# Altium schematic sheet CP2102_USB_To_UART.SchDoc
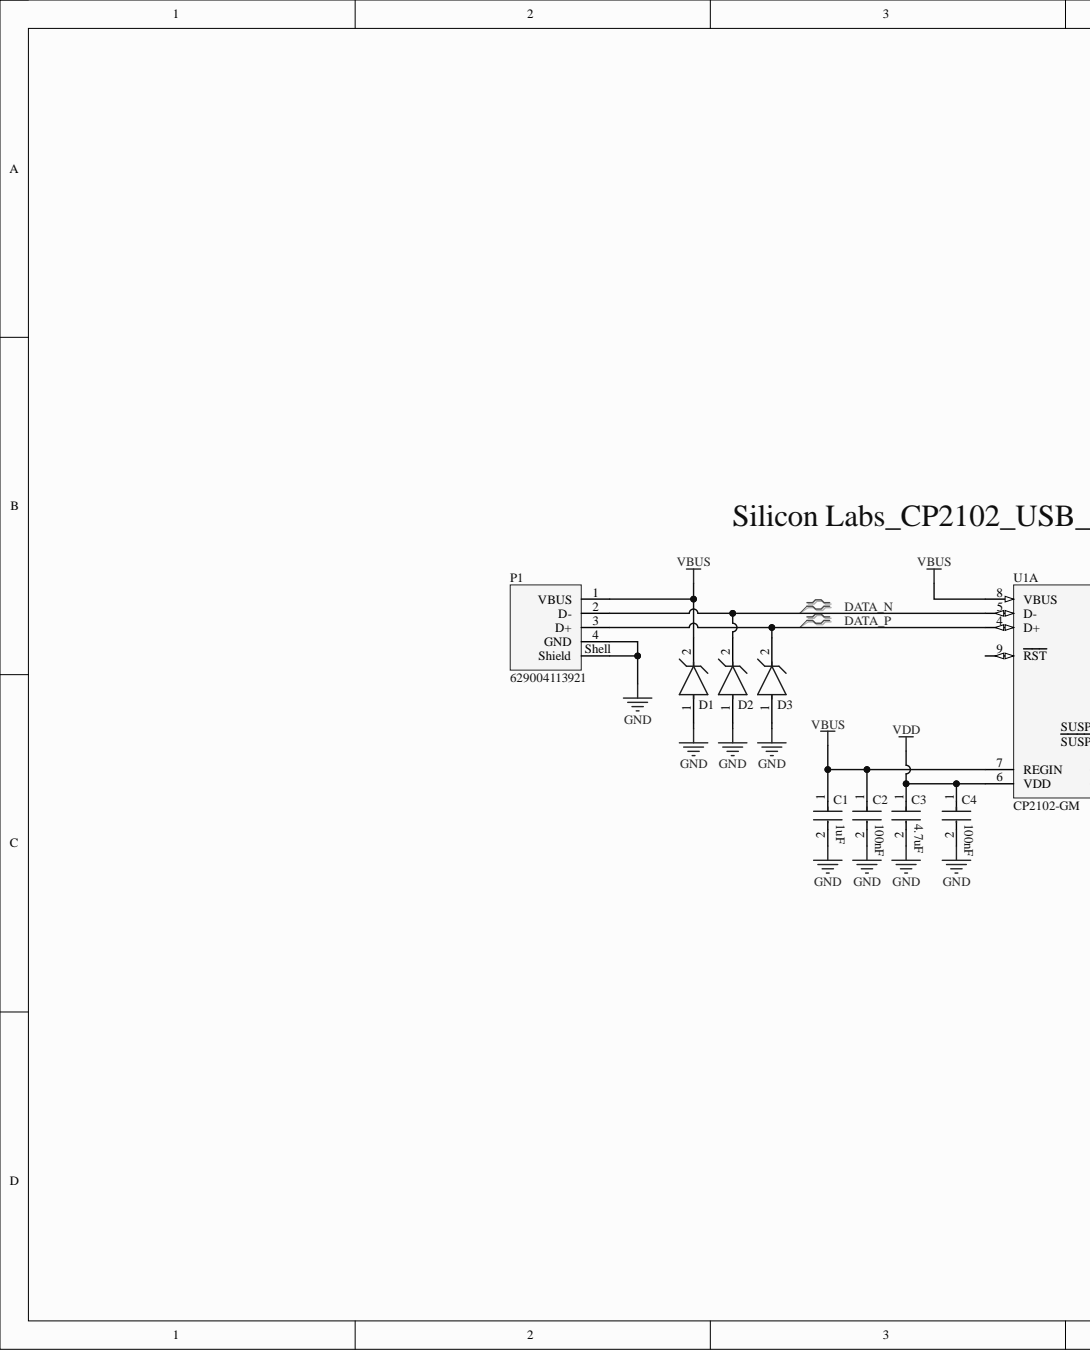
[diagram p0: CP2102_USB_To_UART.SchDoc, left]
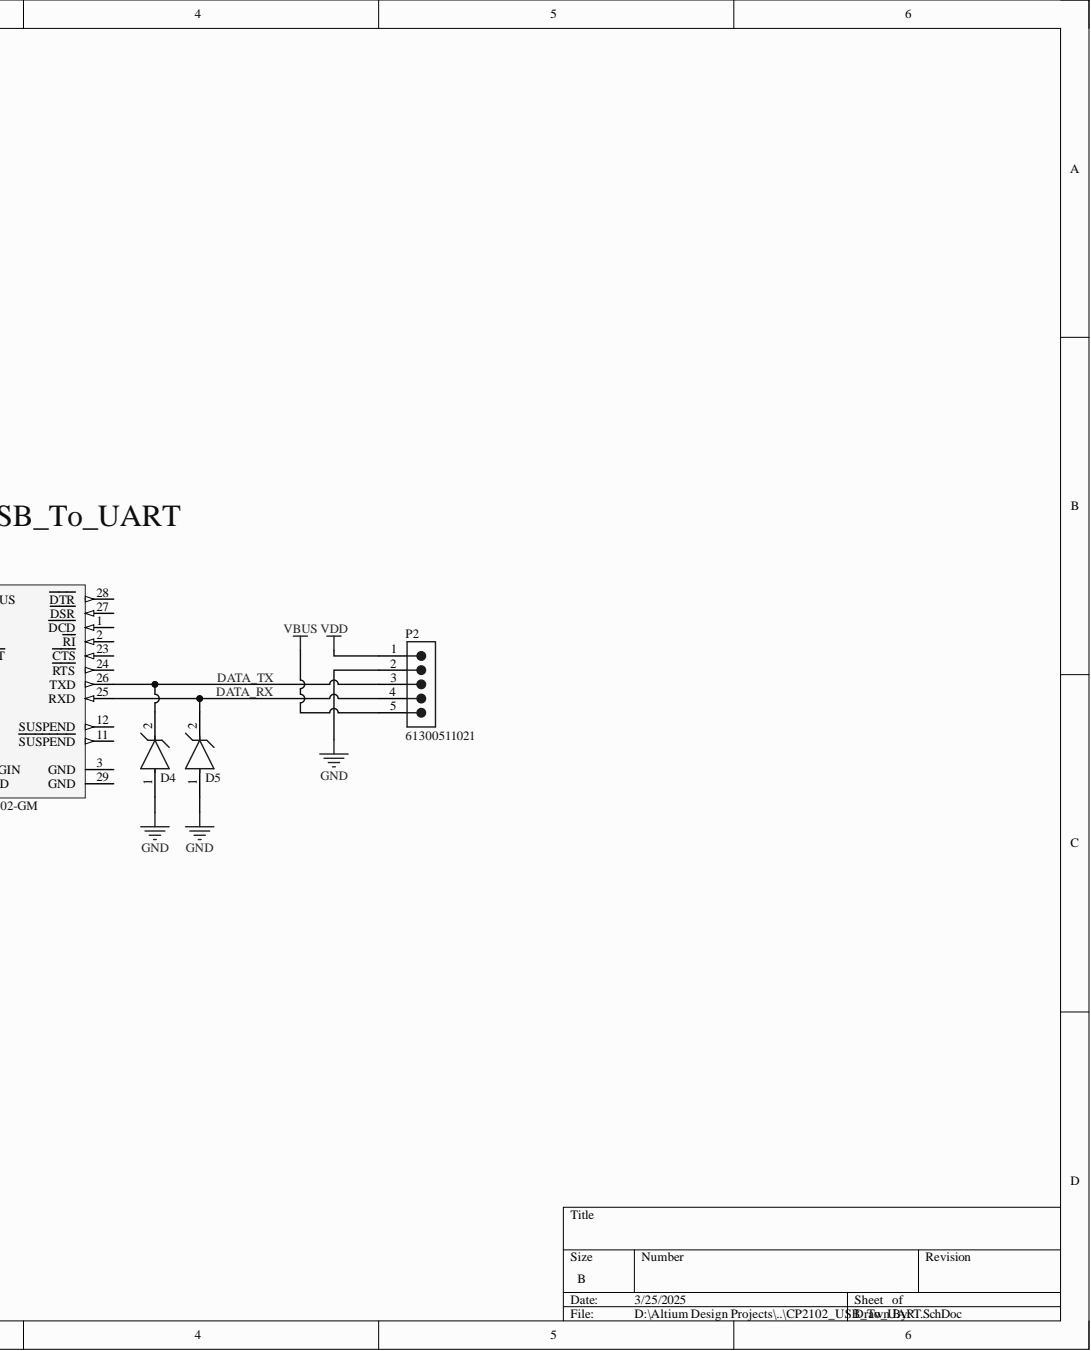
[diagram p1: CP2102_USB_To_UART.SchDoc, right]

## Parts
C1  value 1uF  Capacitance: 1uF  [FP-CC0402-MFG]  (hidden comment: CC0402KRX5R6BB105)
C2  Capacitance: 100nF  [FP-C1005-050-0_05-MFG]  (hidden comment: C1005X7R1C104K050BC)
C3  Capacitance: 4.7uF  [FP-GRM155-0_15-MFG]  (hidden comment: GRM155R61A475MEAAD)
C4  Capacitance: 100nF  [FP-C1005-050-0_05-MFG]  (hidden comment: C1005X7R1C104K050BC)
D1  UCLAMP3601P.TNT  [FP-SLP1006P2-MFG]  (value not drawn)
D2  UCLAMP3601P.TNT  [FP-SLP1006P2-MFG]  (value not drawn)
D3  UCLAMP3601P.TNT  [FP-SLP1006P2-MFG]  (value not drawn)
D4  UCLAMP3601P.TNT  [FP-SLP1006P2-MFG]  (value not drawn)
D5  UCLAMP3601P.TNT  [FP-SLP1006P2-MFG]  (value not drawn)
P1  629004113921  [629004113921]
P2  61300511021  [61300511021]
U1  CP2102-GM  [SLAB-QFN-28-3125x3125TP_N]  (part A)

## Nets
GND: C1.2 C2.2 C3.2 C4.2 D1.1 D2.1 D3.1 D4.1 D5.1 P1.4(GND) P1.Shell(Shield) P2.2
VBUS: C1.1 C2.1 D1.2 P1.1(VBUS) P2.5 U1.7(REGIN) U1.8(VBUS)
VDD: C3.1 C4.1 P2.1 U1.6(VDD)
DATA_N: D2.2 P1.2(D-) U1.5(D-)
DATA_P: D3.2 P1.3(D+) U1.4(D+)
DATA_RX: D5.2 P2.4 U1.25(RXD)
DATA_TX: D4.2 P2.3 U1.26(TXD)

Not connected: U1 1(DCD) 2(RI) 3(GND) 9(RST) 11(SUSPEND) 12(SUSPEND) 23(CTS) 24(RTS) 27(DSR) 28(DTR) 29(GND)

## Library data of the parts
C1 = CMP-03422-000489-1: CAP CER 1UF 10V X5R 0402; Manufacturer: YAGEO; Automotive Grade: NA; Material: Ceramic; Temperature Coefficient: 30ppm/°C; Tolerance: 0.1; Automotive: No; Category: Cap; Component Kind: Standard; ComponentLink2Description: Datasheet; ComponentLink2URL: http://www.yageo.com/documents/recent/UPY-GP_NP0_16V-to-50V_16.pdf; Device Class L1: Passive Components; Device Class L2: Capacitors; Device Class L3: Ceramic Capacitors; Digikey Description: CAP CER 1UF 10V X5R 0402; Footprint Url: http://www.yageo.com/exep/pages/download/literatures/UPY-C_GEN_24.pdf; Height: 0.55mm; Ipc Land Pattern Name: CAPC10050X50; Lead Free: Yes; Library Name: Altium Content Vault; Manufacturer Part Number: CC0402KRX5R6BB105; Mouser Description: Multilayer Ceramic Capacitors MLCC - SMD/SMT 1uF 10V X5R 10%; Octopart: https://octopart.com/cc0402krx5r6bb105-yageo-42244620; Package: CC0402; RoHS: TRUE; Temperature: -55°C to +125°C; Temperature Characteristic: X5R; Temperature Range High: +125°C; Temperature Range Low: -55°C; Voltage Rating: 10 V
C2 C4 = CMP-08246-004182-1: Multilayer Ceramic Capacitors 100nF ±10% 16V X7R SMD 0402; Case Code (Imperial): 0402; Case Code (Metric): 1005; Case/Package: 0402; Component Kind: Standard; Construction: Flat; Depth: 0.5mm; Dielectric: X7R; Dielectric Material: Ceramic; Height: 0.5mm; Lead Free: Lead Free; Length: 1mm; Library Name: Altium Content Vault; Max Operating Temperature: 125°C; Min Operating Temperature: -55°C; Mount: Surface Mount; Number of Pins: 2; Package Quantity: 1; Packaging: Tape and Reel; Radiation Hardening: No; Resistor Type: Ceramic; RoHS Compliant: Yes; Series: C; Termination: SMD/SMT; Thickness: 0.022inch; Tolerance: 10%; Voltage: 16V; Voltage Rating: 16V; Voltage Rating (DC): 16V; Weight: 2.3E-05oz; Width: 0.02inch
C3 = CMP-06035-003573-1: Chip Multilayer Ceramic Capacitors for General Purpose, 0402, 4.7uF, X5R, 15%, 20%, 10V; Case Code (Imperial): 0402; Case Code (Metric): 1005; Case/Package: 0402; Component Kind: Standard; Library Name: Altium Content Vault; Max Operating Temperature: 85°C; Min Operating Temperature: -55°C; Mount: Surface Mount; Packaging: Tape and Reel; Tolerance: 20%; Voltage Rating: 10V
D1-D5 = CMP-56445-000005-1: TVS DIODE 33VWM 60VC SLP1006P2; Clamping Voltage: 60V; Diode Type: ZENER; Direction: Unidirectional; Element Configuration: Single; Leakage Current: 1uA; Max Breakdown Voltage: 45V; Min Breakdown Voltage: 36V; Number of Unidirectional Channels: 1; Peak Pulse Current: 2A; Peak Pulse Power: 125W; Power Dissipation: 170W; Power Line Protection: No; Reverse Standoff Voltage: 33V; Case Code (Imperial): 0402; Case Code (Metric): 1006; Case/Package: SMD/SMT; Clamping Voltage-Max: 60V; Component Kind: Standard; Depth: 0.6mm; Lead Free: Lead Free; Length: 1mm; Library Name: Altium Content Vault; Max Operating Temperature: 125°C; Min Operating Temperature: -40°C; Mount: Surface Mount; Number of Pins: 2; Packaging: Tape and Reel; Radiation Hardening: No; Resistor Type: Zener; RoHS Compliant: Yes; Series: Automotive, AEC-Q100, uClamp®; Working Voltage: 33V
P1 = CMP-1502-03242-2: NexarPartId: 19991169; Connector Type: USB; Contact Resistance: 20mΩ; Current Rating: 1.5A; Gender: Male; Insulation Resistance: 1GΩ; Number of Contacts: 4; Operating Supply Voltage: 30V; USB Standard: USB 2.0; Component Kind: Standard; Contact Plating: Gold; Features: Shielded; Lead Free: Lead Free; Length: 18.8mm; Library Name: Altium Content Vault; Max Operating Temperature: 105°C; Min Operating Temperature: -40°C; Mount: Horizontal, Surface Mount; Number of Pins: 4; Orientation: Horizontal; REACH SVHC: Unknown; RoHS: Compliant; Termination: SMD/SMT
P2 = CMP-1502-01067-3: NexarPartId: 32855525; Connector Type: Male Pin; Contact Resistance: 20mΩ; Current Rating: 3A; Gender: Male; Number of Contacts: 5; Operating Supply Voltage: 250V; Pitch: 2.54mm; Color: Black; Component Kind: Standard; Contact Material: Copper; Contact Mating Length: 5.9944mm; Contact Plating: Gold; Lead Pitch: 2.54mm; Library Name: Altium Content Vault; Mount: Through Hole; Number of Pins: 5; Number of Positions: 5; Number of Rows: 1; Packaging: Bulk; REACH SVHC: Unknown; RoHS: Compliant; Termination: Solder
U1 = CMP-0511-00012-1: Single-Chip USB to UART Bridge, 1024 Bytes EEPROM, -40 to 85 degC, 28-pin QFN, Tube; Manufacturer: Silicon Labs; Internal Oscillator: TRUE; Sub-Family: USB Bridges; Code_IPC: J-STD-020C; Code_JEDEC: MO-220; Component Kind: Standard; ComponentLink1Description: Manufacturer URL; ComponentLink1URL: http://www.silabs.com/; ComponentLink2Description: Datasheet; ComponentLink2URL: http://www.silabs.com/Support%20Documents/TechnicalDocs/CP2102-9.pdf; ComponentLink3Description: Package Specification; ComponentLink3URL: http://www.silabs.com/Support%20Documents/TechnicalDocs/C8051T61x.pdf; DatasheetVersion: Rev. 1.5; EEPROM: 1024 Bytes; Library Name: Altium Content Vault; Mounting Technology: Surface Mount; PackageDescription: 28-Pin Quad-Flat No-Leads Package, Body 5 x 5 mm, Pitch 0.5 mm; PackageReference: QFN-28; PartNumber: CP2102-GM
